# Altium schematic sheet bluetooth.SchDoc(bluetooth)
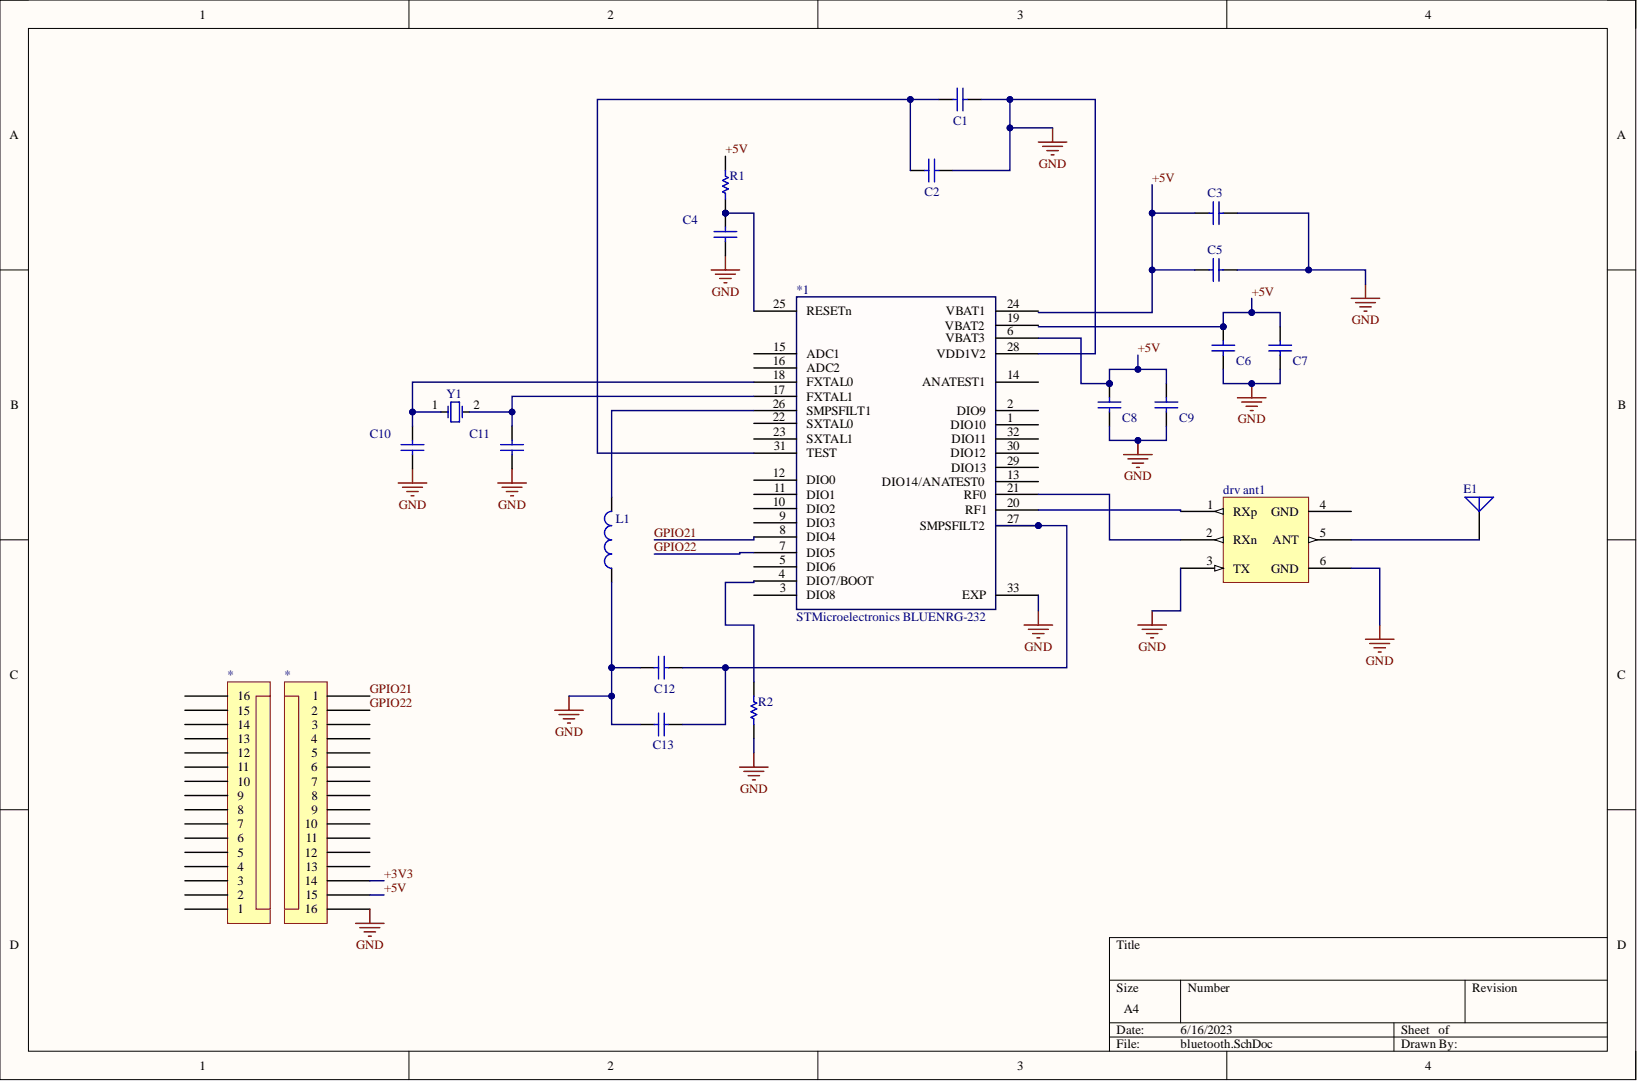
[diagram p0: bluetooth.SchDoc]

## Parts
*  TE Connectivity 1-640456-6  [PCB-345877-1]  (value not drawn)
*  TE Connectivity 1-640456-6  [PCB-345877-1]  (value not drawn)
*1  STMicroelectronics BLUENRG-232
C1  Vitramon VJ1206Y104KXBAT  (value not drawn)
C2  Vitramon VJ1206Y104KXBAT  (value not drawn)
C3  Vitramon VJ1206Y104KXBAT  (value not drawn)
C4  Vitramon VJ1206Y104KXBAT  (value not drawn)
C5  Vitramon VJ1206Y104KXBAT  (value not drawn)
C6  Vitramon VJ1206Y104KXBAT  (value not drawn)
C7  Vitramon VJ1206Y104KXBAT  (value not drawn)
C8  Vitramon VJ1206Y104KXBAT  (value not drawn)
C9  Vitramon VJ1206Y104KXBAT  (value not drawn)
C10  Vitramon VJ1206Y104KXBAT  (value not drawn)
C11  Vitramon VJ1206Y104KXBAT  (value not drawn)
C12  Vitramon VJ1206Y104KXBAT  (value not drawn)
C13  Vitramon VJ1206Y104KXBAT  (value not drawn)
drv_ant1  STMicroelectronics BALF-SPI2-01D3  [PCB-l125dczpquguicaxu7v3-1]  (value not drawn)
E1  TDK ANT016008LCS2442MA2  [PCB-ks6aj7rpabp3fjx33vnu-1]  (value not drawn)
L1  Coiltronics DR74-151-R  [PCB-sbe9akftt04lcn4lkh7e-1]  (value not drawn)
R1  Vishay Dale WSL1206R0300FEA18  (value not drawn)
R2  Vishay Dale WSL1206R0300FEA18  (value not drawn)
Y1  Abracon ABS07-120-32.768KHZ-T  [PCB-r1tll1mdrhwh72easn12-1]  (value not drawn)

## Nets
GND: *.16 *1.28(VDD1V2) *1.33(EXP) C1.1 C2.1 C3.2 C4.1 C5.2 C6.1 C7.1 C8.1 C9.1 C10.1 C11.1 C12.2 C13.2 drv_ant1.3(TX) drv_ant1.6(GND) L1.1 R2.1
+3V3: *.14
+5V: *.15 *1.6(VBAT3) C3.1 C5.1 C6.2 C7.2 C8.2 C9.2 R1.2
GPIO21: *.1 *1.8(DIO4)
GPIO22: *.2 *1.7(DIO5)
Net*1_4: *1.4(DIO7/BOOT) R2.2
Net*1_17: *1.17(FXTAL1) C11.2 Y1.2
Net*1_18: *1.18(FXTAL0) C10.2 Y1.1
Net*1_20: *1.20(RF1) drv_ant1.1(RXp)
Net*1_21: *1.21(RF0) drv_ant1.2(RXn)
Net*1_25: *1.25(RESETn) C4.2 R1.1
Net*1_26: *1.26(SMPSFILT1) L1.2
Net*1_27: *1.27(SMPSFILT2) C12.1 C13.1
Net*1_31: *1.31(TEST) C1.2 C2.2
Netdrv ant1_5: drv_ant1.5(ANT) E1.1

Not connected: * 3 4 5 6 7 8 9 10 11 12 13; *1 1(DIO10) 2(DIO9) 3(DIO8) 5(DIO6) 9(DIO3) 10(DIO2) 11(DIO1) 12(DIO0) 13(DIO14/ANATEST0) 14(ANATEST1) 15(ADC1) 16(ADC2) 19(VBAT2) 22(SXTAL0) 23(SXTAL1) 24(VBAT1) 29(DIO13) 30(DIO12) 32(DIO11); drv_ant1 4(GND)

## Library data of the parts
* * = CMP-5cb5977adcc98372-4: Header, Friction Lock; MTA-100; 16; 250 VAC; Polyester; Tin; Copper Alloy; Tin; ELV Compliant: Compliant; Gender: Male; Lead-Free Status: Lead Free; Mounting Angle: 180 °; Number of Contacts: 16; Pin Pitch: 2.54 mm; Shrouded: No; Voltage Rating (AC): 250 V; Color: White; Component Kind: Standard; Contact Material: Copper Alloy; Contact Plating: Tin; Flammability Rating: UL 94 V-0; Housing Color: White; Housing Material: Polyester; Library Name: My Personal Space; Lifecycle Status: Active; Manufacturer Part Number: 1-640456-6; Mounting Style: Through Hole, Vertical; Number of Positions: 16; Number of Rows: 1; Octopart URL: https://octopart.com/1-640456-6-te+connectivity-46507290; OctopartID: 5cb5977adcc98372; Operating Temperature: 105 °C (max); Orientation: Vertical; Packaging: Bag; REACH SVHC Compliance: No SVHC; RoHS: Compliant; Size-Height: 7.87 mm; Size-Length: 40.6 mm; Size-Width: 5.71 mm; Voltage Rating (DC): 250 V
*1 = CMP-63acafaac706bc6b-4: Bluetooth V5.0 (ble) Smart Soc 2MBPS 3V 32-PIN Vfqfpn Ep T/r; Component Kind: Standard; Library Name: My Personal Space
C1-C13 = CMP-3551491-2: Multilayer Ceramic Capacitors MLCC - SMD/SMT .1uF 100volts 10%; Capacitance: 1e-07 F; Case/Package (SI): 3216; Dielectric Characteristic: X7R; Lead-Free Status: Lead Free; Voltage Rating (DC): 100.0 V; Capacitance Tolerance: ±10%; Case/Package: 1206; Component Kind: Standard; Library Name: My Personal Space; Manufacturer Part Number: VJ1206Y104KXBAT; Number of Pins: 2; Octopart Url: https://octopart.com/vj1206y104kxbat-vishay-39693282; OctopartID: dea215f550260165; RoHS: Compliant
drv_ant1 = CMP-51999d9b45b98e5e-2: Balun 868MHZ-928MHZ 50/50 6UFBGA; Bandwidth: 59.0 MHz; Frequency: 927 MHz (max); Component Kind: Standard; Library Name: My Personal Space; Lifecycle Status: Not Listed by Manufacturer; Manufacturer Part Number: BALF-SPI2-01D3; Octopart URL: https://octopart.com/balf-spi2-01d3-stmicroelectronics-84422982; OctopartID: 51999d9b45b98e5e; Operating Temperature: -40.0 °C (min); Packaging: Cut Tape (CT); RoHS: Compliant
E1 = CMP-5f4394a99d8c8084-2: Antenna Chip Multilayer 2442MHz 6-Pin SMD Punched Paper T/R; Component Kind: Standard; Library Name: My Personal Space; Manufacturer Part Number: ANT016008LCS2442MA2; Mounting Style: Surface Mount; Octopart URL: http://octopart.com/ant016008lcs2442ma2-tdk-48293801; OctopartID: 5f4394a99d8c8084; Packaging: Cut Tape (CT); RoHS: Compliant
L1 = CMP-6f33a4628071b0f4-1: Inductor Power Shielded Drum Core 150uH 20% 100KHz Ferrite 690mA 591mOhm DCR T/R; Inductance: 150 µH; Lead-Free Status: Lead Free; Resistance: 591 mΩ; Shielding: Yes; Component Kind: Standard; Library Name: My Personal Space; Manufacturer Part Number: DR74-151-R; Mounting Style: Surface Mount; Number of Pins: 2; Octopart URL: http://octopart.com/dr74-151-r-coiltronics-39569956; OctopartID: 6f33a4628071b0f4; Packaging: Cut Tape (CT); REACH SVHC Compliance: No SVHC; RoHS: Compliant; Size-Height: 4.35 mm; Size-Length: 7.60 mm; Size-Width: 7.60 mm
R1 R2 = CMP-1870488-2: Current Sense Resistors - SMD 1/2watt .03ohms 1%; Case/Package (SI): 3216; Lead-Free Status: Lead Free; Power Rating: 0.25 W; Resistance: 0.03 Ω; Case/Package: 1206; Component Kind: Standard; Library Name: My Personal Space; Manufacturer Part Number: WSL1206R0300FEA18; Mounting Style: Surface Mount; Octopart Url: https://octopart.com/wsl1206r0300fea18-vishay+dale-39744979; OctopartID: 6930a2643985b6f7; Resistance Tolerance: ±1%; RoHS: Compliant; Size-Height: 0.000635 m; Size-Length: 0.0032004 m; Size-Width: 0.0016002 m
Y1 = CMP-eea426d4086c3163-7: Crystal 0.032768MHz ±20ppm (Tol) 6pF Flexural 60000Ohm 2-Pin CSMD T/R; Lead-Free Status: Lead Free; Load Capacitance: 6.00 pF; Component Kind: Standard; Library Name: My Personal Space; Manufacturer Part Number: ABS07-120-32.768KHZ-T; Mounting Style: Surface Mount; Octopart URL: https://octopart.com/abs07-120-32.768khz-t-abracon-24822702; OctopartID: eea426d4086c3163; Operating Temperature: -40.0 °C (min); Packaging: Reel; RoHS: Compliant
